# Altium schematic sheet WL_IO002_V0.1_0.SchDoc
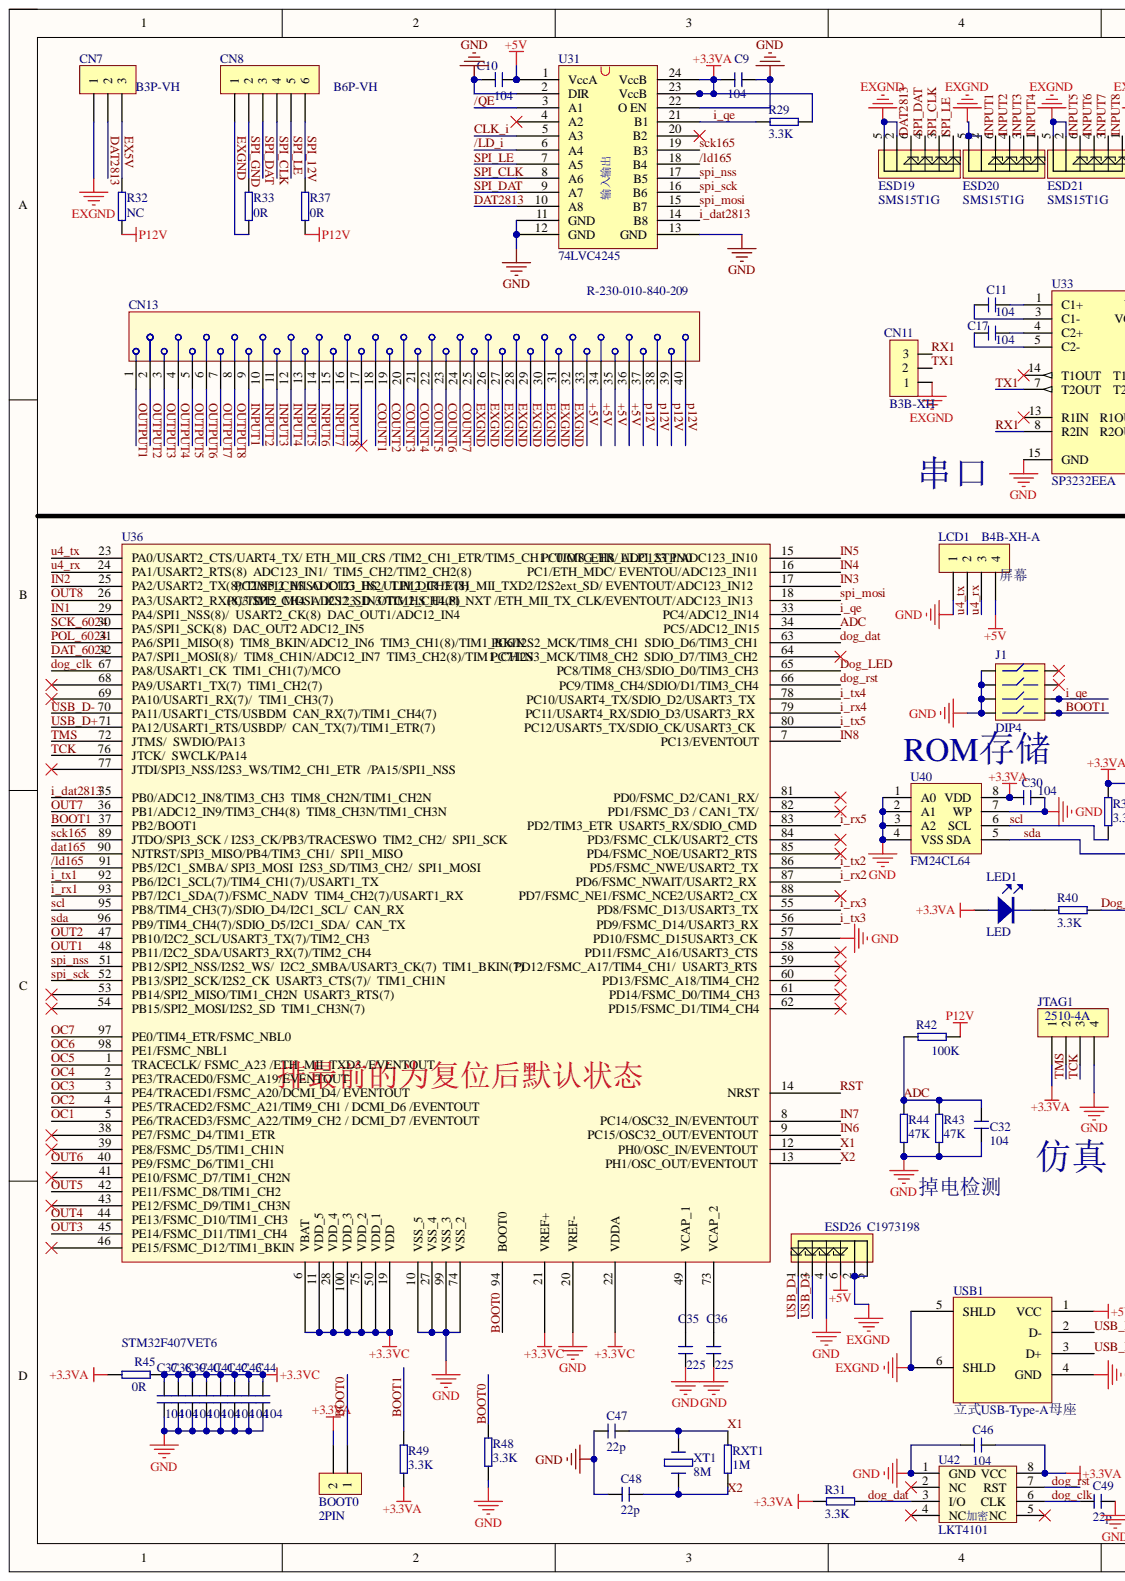
[diagram p0: WL_IO002_V0.1_0.SchDoc, left]
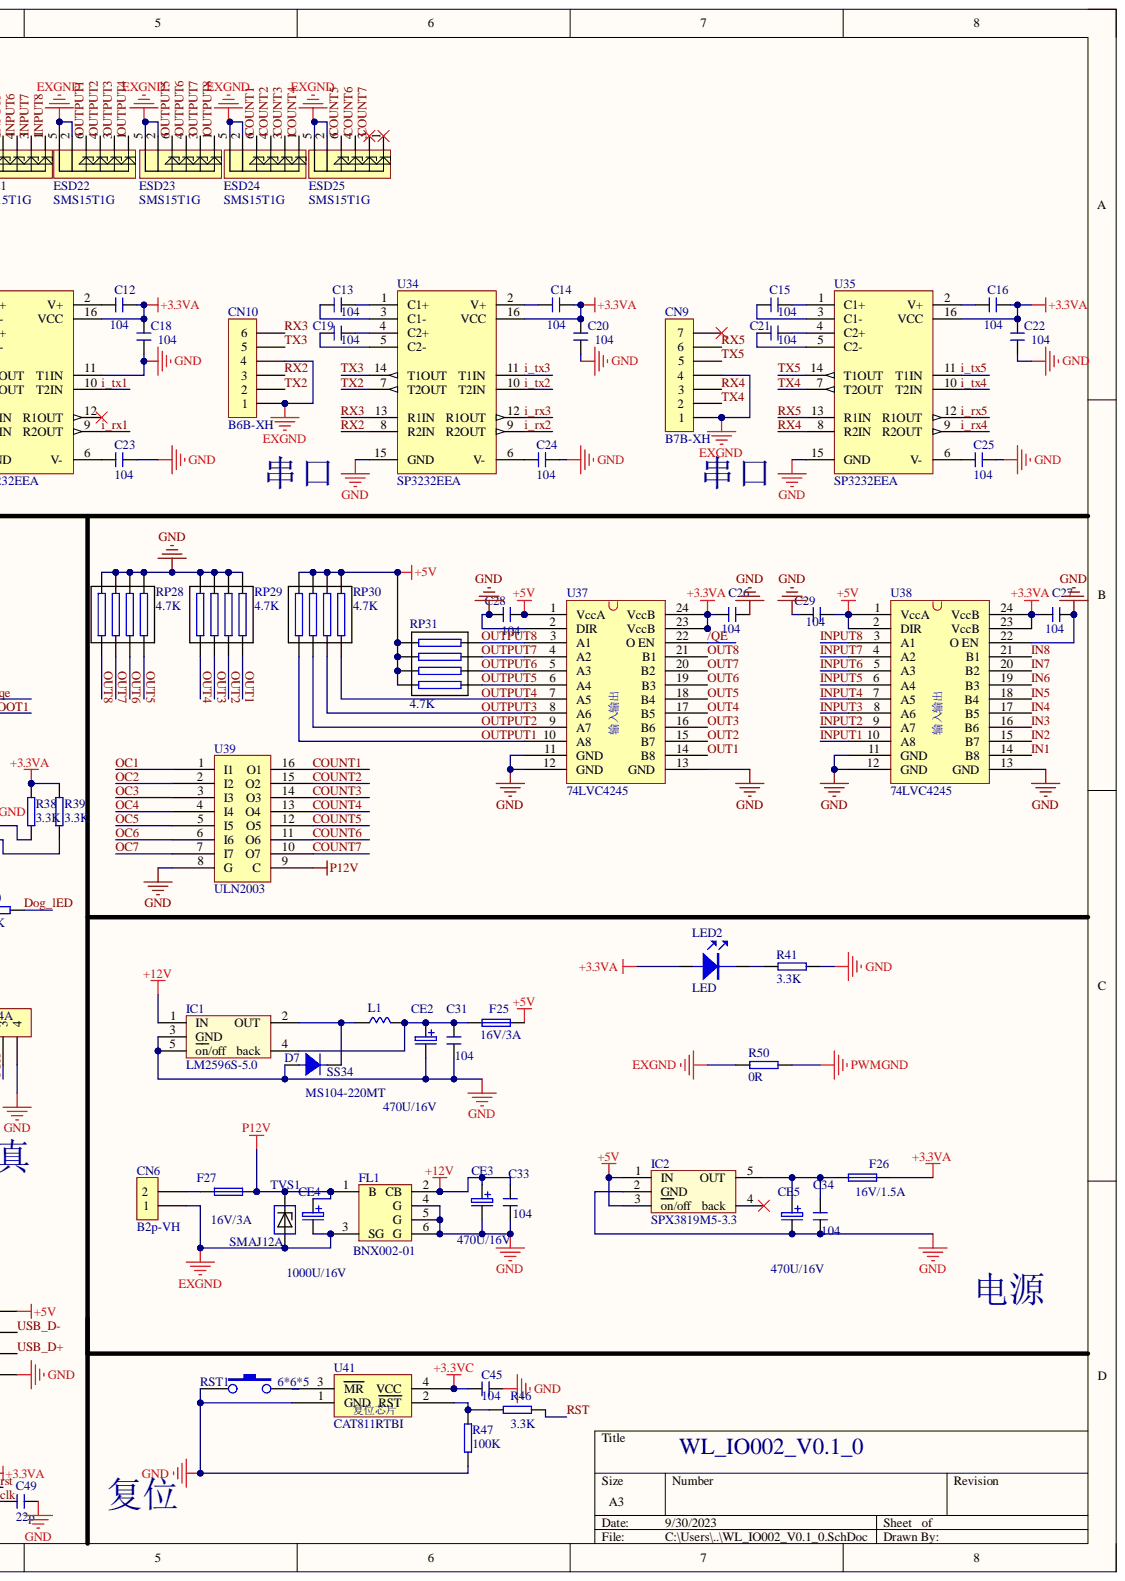
[diagram p1: WL_IO002_V0.1_0.SchDoc, right]

## Parts
BOOT0  2PIN  [2PIN]
C9  104  [C0805]
C10  104  [C0805]
C11  104  [C0805]
C12  104  [C0805]
C13  104  [C0805]
C14  104  [C0805]
C15  104  [C0805]
C16  104  [C0805]
C17  104  [C0805]
C18  104  [C0805]
C19  104  [C0805]
C20  104  [C0805]
C21  104  [C0805]
C22  104  [C0805]
C23  104  [C0805]
C24  104  [C0805]
C25  104  [C0805]
C26  104  [C0805]
C27  104  [C0805]
C28  104  [C0805]
C29  104  [C0805]
C30  104  [C0805]
C31  104  [C0805]
C32  104  [C0805]
C33  104  [C0805]
C34  104  [C0805]
C35  225  [C0805]
C36  225  [C0805]
C37  104  [C0805]
C38  104  [C0805]
C39  104  [C0805]
C40  104  [C0805]
C41  104  [C0805]
C42  104  [C0805]
C43  104  [C0805]
C44  104  [C0805]
C45  104  [C0805]
C46  104  [C0805]
C47  22p  [C0805]
C48  22p  [C0805]
C49  22p  [C0805]
CE2  470U/16V  [C8X10.2SMT]
CE3  470U/16V  [C8X10.2SMT]
CE4  1000U/16V  [C10X10.2SMT]
CE5  470U/16V  [C8X10.2SMT]
CN6  B2p-VH  [B2P-VH]
CN7  B3P-VH  [B3P-VH]
CN8  B6P-VH  [B6P-VH]
CN9  B7B-XH  [B7B-XH-A]
CN10  B6B-XH  [B6B-XH-A]
CN11  B3B-XH  [B3B-XH-A]
CN13  R-230-010-840-209  [Z-2300(40PIN)]
D7  SS34  [DO-214AC]
ESD19  SMS15T1G  [SOT457/SC-74]
ESD20  SMS15T1G  [SOT457/SC-74]
ESD21  SMS15T1G  [SOT457/SC-74]
ESD22  SMS15T1G  [SOT457/SC-74]
ESD23  SMS15T1G  [SOT457/SC-74]
ESD24  SMS15T1G  [SOT457/SC-74]
ESD25  SMS15T1G  [SOT457/SC-74]
ESD26  C1973198  [SOT457/SC-74]
F25  16V/3A  [2920]
F26  16V/1.5A  [1812]
F27  16V/3A  [2920]
FL1  BNX002-01  [BNX002-01]
IC1  LM2596S-5.0  [TO-263]
IC2  SPX3819M5-3.3  [SOT-23-5]
J1  DIP4  [4P_2.54mm拨码开关]
JTAG1  2510-4A  [2510-4A]
L1  MS104-220MT  [L1250]
LCD1  B4B-XH-A  [B4B-XH-A]
LED1  LED  [0805E]
LED2  LED  [0805E]
R29  3.3K  [0805]
R31  3.3K  [0805]
R32  NC  [0805]
R33  0R  [0805]
R37  0R  [0805]
R38  3.3K  [0805]
R39  3.3K  [0805]
R40  3.3K  [0805]
R41  3.3K  [0805]
R42  100K  [0805]
R43  47K  [0805]
R44  47K  [0805]
R45  0R  [0805]
R46  3.3K  [0805]
R47  100K  [0805]
R48  3.3K  [0805]
R49  3.3K  [0805]
R50  0R  [0805]
RP28  4.7K  [RCML08]
RP29  4.7K  [RCML08]
RP30  4.7K  [RCML08]
RP31  4.7K  [RCML08]
RST1  6*6*5  [KEY 6*6]
RXT1  1M  [0805]
TVS1  SMAJ12A  [DO-214AC]
U31  74LVC4245  [SSOP-24W]
U33  SP3232EEA  [SSOP-16W]
U34  SP3232EEA  [SSOP-16W]
U35  SP3232EEA  [SSOP-16W]
U36  STM32F407VET6  [LQFP-100]
U37  74LVC4245  [SSOP-24W]
U38  74LVC4245  [SSOP-24W]
U39  ULN2003  [SOP-3.8-16]
U40  FM24CL64  [SOP-3.8-8]
U41  CAT811RTBI  [SOT-143复位芯片]
U42  LKT4101  [SOP-3.8-8]
USB1  立式USB-Type-A母座  [立式USB-Type-A母座]
XT1  8M  [SMD-5032_2P]

## Nets
+3.3VA: BOOT0.2 C9.1 C12.2 C14.2 C16.2 C18.1 C20.1 C22.1 C26.1 C27.1 C30.1 C46.2 F26.2 JTAG1.1 LED1.A LED2.A R29.2 R31.2 R38.1 R39.1 R45.1 R49.2 U31.23(VccB) U31.24(VccB) U33.16(VCC) U34.16(VCC) U35.16(VCC) U37.23(VccB) U37.24(VccB) U38.23(VccB) U38.24(VccB) U40.8(VDD) U42.8(VCC)
+3.3VC: C37.1 C38.1 C39.1 C40.1 C41.1 C42.1 C43.1 C44.1 C45.2 R45.2 U36.6(VBAT) U36.11(VDD_5) U36.19(VDD) U36.21(VREF+) U36.22(VDDA) U36.28(VDD_4) U36.50(VDD_1) U36.75(VDD_2) U36.100(VDD_3) U41.4(VCC)
+12V: C33.1 CE3.1 FL1.2(CB) IC1.1(IN)
GND: C9.2 C10.2 C18.2 C20.2 C22.2 C23.2 C24.2 C25.2 C26.2 C27.2 C28.2 C29.2 C30.2 C31.2 C32.1 C33.2 C34.2 C35.2 C36.2 C37.2 C38.2 C39.2 C40.2 C41.2 C42.2 C43.2 C44.2 C45.1 C46.1 C47.2 C48.2 C49.2 CE2.2 CE3.2 CE5.2 D7.1 ESD26.4 FL1.4(G) FL1.5(G) FL1.6(G) IC1.3(GND) IC1.5(on/off) IC2.2(GND) J1.1 J1.3 J1.5 J1.7 JTAG1.4 LCD1.1 R41.1 R43.1 R44.1 R47.2 R48.2 RP28.5 RP28.6 RP28.7 RP28.8 RP29.5 RP29.6 RP29.7 RP29.8 RST1.1 U31.2(DIR) U31.11(GND) U31.12(GND) U31.13(GND) U31.22(O_EN) U33.11(T1IN) U33.15(GND) U34.15(GND) U35.15(GND) U36.10(VSS_5) U36.20(VREF-) U36.27(VSS_4) U36.57(PD10/FSMC_D15USART3_CK) U36.74(VSS_2) U36.99(VSS_3) U37.2(DIR) U37.11(GND) U37.12(GND) U37.13(GND) U38.11(GND) U38.12(GND) U38.13(GND) U38.22(O_EN) U39.8(G) U40.1(A0) U40.2(A1) U40.3(A2) U40.4(VSS) U40.7(WP) U41.1(GND) U42.1(GND) USB1.4(GND)
PWMGND: R50.1
+5V: C10.1 C28.1 C29.1 CN13.33 CN13.34 CN13.35 CN13.36 ESD26.6 F25.2 IC2.1(IN) IC2.3(on/off) LCD1.4 RP30.5 RP30.6 RP30.7 RP30.8 RP31.5 RP31.6 RP31.7 RP31.8 U31.1(VccA) U37.1(VccA) U38.1(VccA) U38.2(DIR) USB1.1(VCC)
/ld165: U31.18(B4) U36.91(PB5/I2C1_SMBA/_SPI3_MOSI)
/LD_i: U31.6(A4)
/QE: U31.3(A1) U37.22(O_EN)
ADC: C32.2 R42.1 R43.2 R44.2 U36.34(PC5/ADC12_IN15)
BOOT0: BOOT0.1 R48.1 U36.94(BOOT0)
BOOT1: J1.8 R49.1 U36.37(PB2/BOOT1)
CLK_i: U31.5(A3)
COUNT1: CN13.18 ESD24.6 U39.16(O1)
COUNT2: CN13.19 ESD24.4 U39.15(O2)
COUNT3: CN13.20 ESD24.3 U39.14(O3)
COUNT4: CN13.21 ESD24.1 U39.13(O4)
COUNT5: CN13.22 ESD25.6 U39.12(O5)
COUNT6: CN13.23 ESD25.4 U39.11(O6)
COUNT7: CN13.24 ESD25.3 U39.10(O7)
dat165: CN7.2 ESD19.6 U31.10(A8) U36.90(NJTRST/SPI3_MISO/PB4/TIM3_CH1/)  [also labelled DAT2813]
DAT_6024: U36.32(PA7/SPI1_MOSI(8)/)
dog_clk: C49.1 U36.67(PA8/USART1_CK) U42.6(CLK)
dog_dat: R31.1 U36.63(SDIO_D6/TIM3_CH1) U42.3(I/O)
Dog_lED: R40.1 U36.65(PC8/TIM8_CH3/SDIO_D0/TIM3_CH3)
dog_rst: U36.66(PC9/TIM8_CH4/SDIO/D1/TIM3_CH4) U42.7(RST)
EX5V: CN7.3 R32.2
EXGND: CE4.2 CN6.1 CN7.1 CN8.1 CN9.1 CN9.4 CN10.1 CN10.4 CN11.1 CN13.25 CN13.26 CN13.27 CN13.28 CN13.29 CN13.30 CN13.31 CN13.32 ESD19.2 ESD19.5 ESD20.2 ESD20.5 ESD21.2 ESD21.5 ESD22.2 ESD22.5 ESD23.2 ESD23.5 ESD24.2 ESD24.5 ESD25.2 ESD25.5 ESD26.2 ESD26.5 FL1.3(SG) R33.1 R50.2 TVS1.1 USB1.5(SHLD) USB1.6(SHLD)
i_dat2813: U31.14(B8) U36.35(PB0/ADC12_IN8/TIM3_CH3)
i_qe: J1.6 R29.1 U31.21(B1) U36.33(PC4/ADC12_IN14)
i_rx1: U33.9(R2OUT) U36.93(PB7/I2C1_SDA(7)/FSMC_NADV)
i_rx2: U34.9(R2OUT) U36.87(PD6/FSMC_NWAIT/USART2_RX)
i_rx3: U34.12(R1OUT) U36.55(PD8/FSMC_D13/USART3_TX)
i_rx4: U35.9(R2OUT) U36.79(PC11/USART4_RX/SDIO_D3/USART3_RX)
i_rx5: U35.12(R1OUT) U36.83(USART5_RX/SDIO_CMD)
i_tx1: U33.10(T2IN) U36.92(PB6/I2C1_SCL(7)/TIM4_CH1(7)/USART1_TX)
i_tx2: U34.10(T2IN) U36.86(PD5/FSMC_NWE/USART2_TX)
i_tx3: U34.11(T1IN) U36.56(PD9/FSMC_D14/USART3_RX)
i_tx4: U35.10(T2IN) U36.78(PC10/USART4_TX/SDIO_D2/USART3_TX)
i_tx5: U35.11(T1IN) U36.80(PC12/USART5_TX/SDIO_CK/USART3_CK)
IN1: U36.29(PA4/SPI1_NSS(8)/) U38.14(B8)
IN2: U36.25(PA2/USART2_TX(8)/TIM5_CH3) U38.15(B7)
IN3: U36.17(/ETH_MII_TXD2/I2S2ext_SD/_EVENTOUT/ADC123_IN12) U38.16(B6)
IN4: U36.16(PC1/ETH_MDC/_EVENTOU/ADC123_IN11) U38.17(B5)
IN5: U36.15(PC0/OTG_HS_ULPI_STP/ADC123_IN10) U38.18(B4)
IN6: U36.9(PC15/OSC32_OUT/EVENTOUT) U38.19(B3)
IN7: U36.8(PC14/OSC32_IN/EVENTOUT) U38.20(B2)
IN8: U36.7(PC13/EVENTOUT) U38.21(B1)
INPUT1: CN13.9 ESD20.6 U38.10(A8)
INPUT2: CN13.10 ESD20.4 U38.9(A7)
INPUT3: CN13.11 ESD20.3 U38.8(A6)
INPUT4: CN13.12 ESD20.1 U38.7(A5)
INPUT5: CN13.13 ESD21.6 U38.6(A4)
INPUT6: CN13.14 ESD21.4 U38.5(A3)
INPUT7: CN13.15 ESD21.3 U38.4(A2)
INPUT8: CN13.16 ESD21.1 U38.3(A1)
OC1: U36.5(PE6/TRACED3/FSMC_A22/TIM9_CH2_/_DCMI_D7_/EVENTOUT) U39.1(I1)
OC2: U36.4(PE5/TRACED2/FSMC_A21/TIM9_CH1_/_DCMI_D6_/EVENTOUT) U39.2(I2)
OC3: U36.3(PE4/TRACED1/FSMC_A20/DCMI_D4/_EVENTOUT) U39.3(I3)
OC4: U36.2(PE3/TRACED0/FSMC_A19/EVENTOUT) U39.4(I4)
OC5: U36.1(TRACECLK/_FSMC_A23_/ETH_MII_TXD3) U39.5(I5)
OC6: U36.98(PE1/FSMC_NBL1) U39.6(I6)
OC7: U36.97(PE0/TIM4_ETR/FSMC_NBL0) U39.7(I7)
OUT1: RP29.4 U36.48(PB11/I2C2_SDA/USART3_RX(7)/TIM2_CH4) U37.14(B8)
OUT2: RP29.3 U36.47(PB10/I2C2_SCL/USART3_TX(7)/TIM2_CH3) U37.15(B7)
OUT3: RP29.2 U36.45(PE14/FSMC_D11/TIM1_CH4) U37.16(B6)
OUT4: RP29.1 U36.44(PE13/FSMC_D10/TIM1_CH3) U37.17(B5)
OUT5: RP28.1 U36.42(PE11/FSMC_D8/TIM1_CH2) U37.18(B4)
OUT6: RP28.2 U36.40(PE9/FSMC_D6/TIM1_CH1) U37.19(B3)
OUT7: RP28.3 U36.36(PB1/ADC12_IN9/TIM3_CH4(8)) U37.20(B2)
OUT8: RP28.4 U36.26(PA3/USART2_RX(8)/TIM5_CH4) U37.21(B1)
OUTPUT1: CN13.1 ESD22.6 RP30.1 U37.10(A8)
OUTPUT2: CN13.2 ESD22.4 RP30.2 U37.9(A7)
OUTPUT3: CN13.3 ESD22.3 RP30.3 U37.8(A6)
OUTPUT4: CN13.4 ESD22.1 RP30.4 U37.7(A5)
OUTPUT5: CN13.5 ESD23.6 RP31.1 U37.6(A4)
OUTPUT6: CN13.6 ESD23.4 RP31.2 U37.5(A3)
OUTPUT7: CN13.7 ESD23.3 RP31.3 U37.4(A2)
OUTPUT8: CN13.8 ESD23.1 RP31.4 U37.3(A1)
p12V: CE4.1 CN13.37 CN13.38 CN13.39 CN13.40 F27.2 FL1.1(B) R32.1 R37.1 R42.2 TVS1.2 U39.9(C)
POL_6024: U36.31(PA6/SPI1_MISO(8))
RST: R46.2 U36.14(NRST)
RX1: CN11.3 U33.8(R2IN)
RX2: CN10.3 U34.8(R2IN)
RX3: CN10.6 U34.13(R1IN)
RX4: CN9.3 U35.8(R2IN)
RX5: CN9.6 U35.13(R1IN)
sck165: U31.19(B3) U36.89(JTDO/SPI3_SCK_/_I2S3_CK/PB3/TRACESWO)
SCK_6024: U36.30(PA5/SPI1_SCK(8))
scl: R38.2 U36.95(PB8/TIM4_CH3(7)/SDIO_D4/I2C1_SCL/) U40.6(SCL)
sda: R39.2 U36.96(PB9/TIM4_CH4(7)/SDIO_D5/I2C1_SDA/) U40.5(SDA)
SPI_12V: CN8.6 R37.2
SPI_CLK: CN8.4 ESD19.3 U31.8(A6)
SPI_DAT: CN8.3 ESD19.4 U31.9(A7)
SPI_GND: CN8.2 R33.2
SPI_LE: CN8.5 ESD19.1 U31.7(A5)
spi_mosi: U31.15(B7) U36.18
spi_nss: U31.17(B5) U36.51(PB12/SPI2_NSS/I2S2_WS/)
spi_sck: U31.16(B6) U36.52(PB13/SPI2_SCK/I2S2_CK)
TCK: JTAG1.3 U36.76(JTCK/)
TMS: JTAG1.2 U36.72(JTMS/)
TX1: CN11.2 U33.7(T2OUT)
TX2: CN10.2 U34.7(T2OUT)
TX3: CN10.5 U34.14(T1OUT)
TX4: CN9.2 U35.7(T2OUT)
TX5: CN9.5 U35.14(T1OUT)
u4_rx: LCD1.3 U36.24(PA1/USART2_RTS(8))
u4_tx: LCD1.2 U36.23
USB_D+: ESD26.3 U36.71(PA12/USART1_RTS/USBDP/) USB1.3(D+)
USB_D-: ESD26.1 U36.70(PA11/USART1_CTS/USBDM) USB1.2(D-)
X1: C47.1 RXT1.2 U36.12(PH0/OSC_IN/EVENTOUT) XT1.2
X2: C48.1 RXT1.1 U36.13(PH1/OSC_OUT/EVENTOUT) XT1.1
NetC11_1: C11.1 U33.3(C1-)
NetC11_2: C11.2 U33.1(C1+)
NetC12_1: C12.1 U33.2(V+)
NetC13_1: C13.1 U34.3(C1-)
NetC13_2: C13.2 U34.1(C1+)
NetC14_1: C14.1 U34.2(V+)
NetC15_1: C15.1 U35.3(C1-)
NetC15_2: C15.2 U35.1(C1+)
NetC16_1: C16.1 U35.2(V+)
NetC17_1: C17.1 U33.5(C2-)
NetC17_2: C17.2 U33.4(C2+)
NetC19_1: C19.1 U34.5(C2-)
NetC19_2: C19.2 U34.4(C2+)
NetC21_1: C21.1 U35.5(C2-)
NetC21_2: C21.2 U35.4(C2+)
NetC23_1: C23.1 U33.6(V-)
NetC24_1: C24.1 U34.6(V-)
NetC25_1: C25.1 U35.6(V-)
NetC31_1: C31.1 CE2.1 F25.1 IC1.4(back) L1.2
NetC34_1: C34.1 CE5.1 F26.1 IC2.5(OUT)
NetC35_1: C35.1 U36.49(VCAP_1)
NetC36_1: C36.1 U36.73(VCAP_2)
NetCN6_2: CN6.2 F27.1
NetD7_2: D7.2 IC1.2(OUT) L1.1
NetLED1_K: LED1.K R40.2
NetLED2_K: LED2.K R41.2
NetR46_1: R46.1 R47.1 U41.2(RST)
NetRST1_2: RST1.2 U41.3(MR)

Not connected: CN9 7; CN13 17; ESD25 1; IC2 4(back); J1 2 4; U31 4(A2) 20(B2); U33 12(R1OUT) 13(R1IN) 14(T1OUT); U36 38(PE7/FSMC_D4/TIM1_ETR) 39(PE8/FSMC_D5/TIM1_CH1N) 41(PE10/FSMC_D7/TIM1_CH2N) 43(PE12/FSMC_D9/TIM1_CH3N) 46(PE15/FSMC_D12/TIM1_BKIN) 53(PB14/SPI2_MISO/TIM1_CH2N) 54(PB15/SPI2_MOSI/I2S2_SD) 58(PD11/FSMC_A16/USART3_CTS) 59(USART3_RTS) 60(PD13/FSMC_A18/TIM4_CH2) 61(PD14/FSMC_D0/TIM4_CH3) 62(PD15/FSMC_D1/TIM4_CH4) 64(SDIO_D7/TIM3_CH2) 68(PA9/USART1_TX(7)) 69(PA10/USART1_RX(7)/) 77(JTDI/SPI3_NSS/I2S3_WS/TIM2_CH1_ETR) 81(PD0/FSMC_D2/CAN1_RX/) 82(PD1/FSMC_D3_/_CAN1_TX/) 84(PD3/FSMC_CLK/USART2_CTS) 85(PD4/FSMC_NOE/USART2_RTS) 88(PD7/FSMC_NE1/FSMC_NCE2/USART2_CX); U42 2(NC) 4 5(加密_NC)

## Library data of the parts
BOOT0 = 2PIN: 插针; Component Kind: Standard; Library Name: PIN.SchLib
C9-C49 = CAP: 贴片陶瓷电容; Component Kind: Standard; Library Name: RCL.SchLib
CE2-CE5 = CAP2: 电解电容; Component Kind: Standard; Library Name: RCL.SchLib
CN6 = 2PIN: Connector; Component Kind: Standard; Library Name: PIN.SchLib
CN7 = CON3: Connector; Component Kind: Standard; Library Name: CONNECTER.SchLib
CN8 CN10 = CON6: Connector; Component Kind: Standard; Library Name: CONNECTER.SchLib
CN9 = CON7: Connector; Component Kind: Standard; Library Name: CONNECTER.SchLib
CN11 = 3PIN: Connector; Component Kind: Standard; Library Name: PIN.SchLib
CN13 = 40PIN: 牛角插座; Component Kind: Standard; Library Name: PIN.SchLib
D7 = D: 肖特基二极管; Component Kind: Standard; Library Name: D.SchLib
ESD19-ESD26 = PESD12VS4UD: ESD保护; Component Kind: Standard; Library Name: D.SchLib
F25-F27 = FUSE: PTC可恢复保险丝; Component Kind: Standard; Library Name: other.SchLib
FL1 = BNX002-01: 滤波器; Component Kind: Standard; Library Name: RCL.SchLib
IC1 = LM2576: 电源芯片; Component Kind: Standard; Library Name: Q.SchLib
IC2 = SPX3819: 电源芯片; Component Kind: Standard; Library Name: Q.SchLib
J1 = DIP4: 拨码开关; Component Kind: Standard; Library Name: other.SchLib
JTAG1 LCD1 = 4PIN: Connector; Component Kind: Standard; Library Name: PIN.SchLib
L1 = L: 贴片电感; Component Kind: Standard; Library Name: RCL.SchLib
LED1 LED2 = LED: 红色发光二极管; Component Kind: Standard; Library Name: D.SchLib
R29 R31-R33 R37-R50 RXT1 = RES: Resistor; Component Kind: Standard; Library Name: RCL.SchLib
RP28-RP31 = RCML08: 排阻; Component Kind: Standard; Library Name: RCL.SchLib
RST1 = KEY: Component Kind: Standard; Library Name: other.SchLib
TVS1 = SMAJ12A: 单向; Component Kind: Standard; Library Name: D.SchLib
U31 U37 U38 = 74LVC4245: 3.3V-5V电平转换门电路; Component Kind: Standard; Library Name: IC.SchLib
U33-U35 = SP3232EEN: RS232串口转换芯片; Component Kind: Standard; Library Name: IC.SchLib
U36 = STM32F407VET6: ARM芯片; Component Kind: Standard; Library Name: IC.SchLib
U39 = ULN2003: 达林顿二极管阵列; Component Kind: Standard; Library Name: IC.SchLib
U40 = 24C00: 铁电存储器; Component Kind: Standard; Library Name: IC.SchLib
U41 = CAT811: 复位芯片; Component Kind: Standard; Library Name: IC.SchLib
U42 = LKT4101: 加密芯片; Component Kind: Standard; Library Name: IC.SchLib
USB1 = 立式USB-Type-A母座: USB座; Component Kind: Standard; Library Name: PIN.SchLib
XT1 = JT: 晶振; Component Kind: Standard; Library Name: other.SchLib
